# Altium schematic sheet Inresol_grid_inverter_Main.SchDoc(Inresol_grid_inverter_Main) (continued)

## Library data of the parts
C100 = C-330uF-25V-Elec-8x10-EMEA-06-09-2017: Cap 8x10 SM Alum 25V 20%; MFR: Panasonic; MFR PN: EEE-FK1E331P; Future PN (CT): TBD; Rating: 25V 20%; Component Kind: Standard; ComponentLink1Description: Datasheet; ComponentLink1URL: https://industrial.panasonic.com/ww/products/capacitors/aluminum-capacitors/aluminum-cap-smd/fk-v/EEEFK1E331P; Future PN (TR): TBD; Library Name: Inresol_grid_inverter.SchLib
C101 = C-22uF-25V-Cer-1210: Cap 1210 SM X7R 25V 10%; MFR: MURATA; MFR PN: GRM32ER71E226KE15L; Future PN (CT): TBD; Future PN (TR): 12103C226KATM; Rating: 25V 10%; Component Kind: Standard; ComponentLink1Description: Datasheet; ComponentLink1URL: http://search.murata.co.jp/Ceramy/image/img/A01X/partnumbering_e_01.pdf; Library Name: Future Capacitors.SCHLIB; Verified: AD030615
C102 C103 C106 C107 = C-820uF-450V-Elec-40x60-EMEA-19-10-2016: Cap Alu Elec 450V 820 uF 110mOhm esr 5k hrs @105°C; MFR: Vishay; MFR PN: MAL229967821E3; Future PN (CT): TBA; Component Kind: Standard; ComponentLink1Description: Datasheet; ComponentLink1URL: http://www.vishay.com/docs/28432/299phl4tsi.pdf; Future PN (TR): TBA; Library Name: Inresol_grid_inverter.SchLib
C104 C105 C108 C109 = C-4.7nF-300V-PolyF-C-12.5x5_EMEA_20122017: Cap 12.5x5 TH Polyprop 300V 20% Y2; MFR: Epcos; MFR PN: B32021A3472M000; Future PN (CT): TBD; Rating: 300Vac 20% Y2; Component Kind: Standard; ComponentLink1Description: Datasheet; ComponentLink1URL: http://www.vishay.com/docs/28114/mkp3386y2.pdf; Future PN (TR): TBD; Library Name: Inresol_grid_inverter.SchLib
C122 C129 C137 C139 C145 C146 C148 C154 = C-100pF-25V-Cer-0603: Cap 0603 SM X7R 25V 10%; MFR: AVX; MFR PN: 06033C101KAT2A; Future PN (CT): 06033C101KATN-CT; Future PN (TR): 06033C101KATN; Rating: 25V 10%; Component Kind: Standard; ComponentLink1Description: Datasheet; ComponentLink1URL: http://www.avx.com/docs/Catalogs/cx7r.pdf; Library Name: Future Capacitors.SCHLIB; Verified: AD030615
C127 C131 C133 C134 C136 = C-4.7uF-50V-Cer-1206-emea-8-10-15: Cap 1206 SM X7R 50V 10%; MFR: SAMSUNG; MFR PN: CL31B475KBHNNNE; Apr'd: raised emea 8th Oct 2015; Future PN (CT): TBA; Future PN (TR): 12065C475KATM; Rating: 50V 10%; Component Kind: Standard; ComponentLink1Description: Datasheet; ComponentLink1URL: http://www.samsungsem.com/servlet/ProductSearchView?page=1&searchIdx=1&lang=kr&partnum=1&find_val=CL31B475KBHNNNE; Library Name: EMEA CAPACITORS_FEB2016.SchLib
C128 C135 C142 C159 C161 C162 C164 = C-100nF-50V-Cer-0603: Cap 0603 SM X7R 50V 10%; MFR: AVX; MFR PN: 06035C104K4T2A; Future PN (CT): 06035C104K4TN-CT; Future PN (TR): 06035C104K4TN; Rating: 50V 10%; Component Kind: Standard; ComponentLink1Description: Datasheet; ComponentLink1URL: http://www.avx.com/docs/Catalogs/cx7r.pdf; Library Name: EMEA CAPACITORS_FEB2016.SchLib; Verified: AD030615
C130 C138 C147 C149 = C-330pF-25V-Cer-0603: Cap 0603 SM X7R 25V 1%; MFR: AVX; MFR PN: 06033A331FAT2A; Future PN (CT): TBD; Future PN (TR): 06033A331FATN; Rating: 25V 1%; Component Kind: Standard; ComponentLink1Description: Datasheet; ComponentLink1URL: http://www.avx.com/docs/Catalogs/ccog.pdf; Library Name: Future Capacitors.SCHLIB; Verified: AD030615
C140 C141 = C-10uF-50V-Cer-1206: Cap 1206 SM X5R 50V 20%; MFR: TDK; MFR PN: C3216X5R1H106M160AB; Future PN (CT): TBD; Future PN (TR): 12065D106MATN; Rating: 50V 20%; Component Kind: Standard; ComponentLink1Description: Datasheet; ComponentLink1URL: http://product.tdk.com/capacitor/mlcc/en/documents/mlcc_commercial_general_en.pdf; Library Name: EMEA CAPACITORS_FEB2016.SchLib; Verified: AD030615
C143 = C-470uF-16V-Elec-8x10-EMEA-28-10-2016: Cap 8X10 SM Alum 16V 20% 2000hrs 105degree; MFR: Panasonic; MFR PN: EEE-FK1C471P; Rating: 16V 20%; Component Kind: Standard; ComponentLink1Description: Datasheet; ComponentLink1URL: http://industrial.panasonic.com/cdbs/www-data/pdf/RDE0000/ABA0000C31.pdf; Library Name: Inresol_grid_inverter.SchLib
C144 = C-1uF-25V-Cer-0603: Cap 0603 SM X5R 25V 10%; MFR: YAGEO; MFR PN: CC0603KRX5R8BB105; Future PN (CT): 06033D105KATN-CT; Future PN (TR): 06033D105KATN; Rating: 25V 10%; Component Kind: Standard; ComponentLink1Description: Datasheet; ComponentLink1URL: http://www.yageo.com/documents/recent/UPY-GPHC_X5R_4V-to-50V_12.pdf; Library Name: Future Capacitors.SCHLIB; Verified: AD030615
C150 C151 = C-22uF-16V-Tant-1210: Cap 1210 SM Tant 16V 10%; MFR: AVX; MFR PN: TPSB226K016R0600; Future PN (CT): 226K16BP0600-CT; Future PN (TR): 226K16BP0600; Rating: 16V 10%; Component Kind: Standard; ComponentLink1Description: Datasheet; ComponentLink1URL: http://www.avx.com/docs/masterpubs/tantniob.pdf; Library Name: Future Capacitors.SCHLIB; Verified: AD030615
C152 = C-10nF-50V-Cer-1206: Cap 1206 SM X7R 50V 10%; MFR: AVX; MFR PN: 12065C103KAT2A; Changes: changed footprint to 1206 to fit track between pads.; Future PN (CT): 12065C103KATN-CT; Future PN (TR): 12065C103KATN; Rating: 50V 10%; Changes-date: 20170215; Component Kind: Standard; ComponentLink1Description: Datasheet; ComponentLink1URL: http://www.avx.com/docs/Catalogs/ccog.pdf; Library Name: Future Capacitors.SCHLIB
C153 = C-2.2uF-25V-Cer-0805-emea-8-10-15: Cap 0805 SM X7R 25V 10%; MFR: SAMSUNG; MFR PN: CL21B225KAFNNNG; Apr'd: raised emea 8th Oct 2015; Future PN (CT): TBA; Future PN (TR): 08053C225KATN; Rating: 25V 10%; Component Kind: Standard; ComponentLink1Description: Datasheet; ComponentLink1URL: http://www.samsungsem.com/servlet/ProductSearchView?page=1&searchIdx=1&lang=kr&partnum=1&find_val=CL31B475KBHNNNE; Library Name: EMEA CAPACITORS_FEB2016.SchLib
C155-C158 = C-22uF-10V-Cer-1206: Cap 1206 SM X5R 10V 20%; MFR: AVX; MFR PN: 1206ZD226MAT2A; Future PN (CT): TBD; Future PN (TR): 1206ZD226MATN; Rating: 10V 20%; Component Kind: Standard; ComponentLink1Description: Datasheet; ComponentLink1URL: http://www.avx.com/docs/Catalogs/cx5r.pdf; Library Name: Future Capacitors.SCHLIB; Verified: AD030615
C160 = C-6.8nF-50V-Cer-0603-EMEA-21-07-16: Cap 0603 SM X7R 50V 10%; MFR: VISHAY; MFR PN: VJ0603Y682KXACW1BC; Future PN (CT): 06035C682KATN-CT; Future PN (TR): 06035C682KATN; Rating: 50V 10%; Component Kind: Standard; ComponentLink1Description: Datasheet; ComponentLink1URL: http://www.vishay.com/docs/28503/x7rlv.pdf; Library Name: Lucy Zodion LoRa SLC.SchLib
C163 C165 = C-10pF-10V-Cer-0603: Cap 0603 SM X7R 10V 10%; MFR: AVX; MFR PN: 0603ZA100KAT2A; Future PN (CT): TBD; Future PN (TR): 0603ZA100KATN; Rating: 10V 10%; Component Kind: Standard; ComponentLink1Description: Datasheet; ComponentLink1URL: http://www.avx.com/docs/Catalogs/cx7r.pdf; Library Name: Future Capacitors.SCHLIB; Verified: AD030615
D100 = D-SOD123-MMSD4148T: Diode, Switching, Si, 200mA; MFR: ON SEMI; MFR PN: MMSD4148T1G; Apr'd: YES; Future PN (CT): MMSD4148T1-CT; Future PN (TR): MMSD4148T1-LF; Rating: 200mA 100V; Component Kind: Standard; ComponentLink1Description: Datasheet; ComponentLink1URL: http://www.onsemi.com/pub_link/Collateral/MMSD4148T1-D.PDF; Library Name: Future Semiconductors.SCHLIB
D101 = D-SMA-SMAJ15A-EMEA-06-09-2017: Diode TVS Uni 17.6V 400W SMA; MFR: ST; MFR PN: SMAJ15A-TR; Apr'd: YES; Future PN (CT): TBD; Component Kind: Standard; ComponentLink1Description: Datasheet; ComponentLink1URL: http://uk.futureelectronics.com/en/technologies/electromechanical/circuit-protection/tvs-diodes/Pages/7715931-SMAJ15A-TR.aspx?gclid=EAIaIQobChMIpp620-2Q1gIVyp3tCh1UKQcuEAAYBCAAEgK-VvD_BwE; Future PN (TR): TBD; Rating: 17.6V 400W; Library Name: Inresol_grid_inverter.SchLib
D102 D103 = D-SMA-MBRA340T3G: Diode Schottky 40V 3A SMD; MFR: On Semi; Apr'd: YES; Future PN (CT): MBRA340TR-CT; Future PN (TR): MBRA340TR; Component Kind: Standard; ComponentLink1Description: Datasheet; ComponentLink1URL: http://www.onsemi.com/pub_link/Collateral/MBRA340T3-D.PDF; Library Name: EMEA SEMICONDUCTORS_FEB2016.SchLib; MFR PN: MBRA340T3G
D104 D105 = D-SOD123-MMSZ5250B-20V-EMEA-19-05-16: Diode Zener 20V 500mW; MFR: DIODES INC; Apr'd: RAISED EMEA 19-05-16; Future PN (CT): TBD; Component Kind: Standard; ComponentLink1Description: Datasheet; ComponentLink1URL: http://www.farnell.com/datasheets/1670964.pdf; Future PN (TR): TBD; Library Name: EMEA SEMICONDUCTORS_FEB2016.SchLib; MFR PN: MMSZ5250B; Signal Integrity: D Zener; Simulation: ZENER; Value: MMSZ5250B
D106 D107 = D-SOD323-SD107WS-7-F: Diode Schottky 30V 750mA SMD; MFR: DIO; Apr'd: YES; Future PN (CT): SD107WSTR-CT; Future PN (TR): SD107WSTR; Component Kind: Standard; ComponentLink1Description: Datasheet; ComponentLink1URL: http://www.diodes.com/datasheets/ds30129.pdf; Library Name: Future Semiconductors.SCHLIB
  D106: MFR PN: SD107WS-7-F
FID1-FID8 = W-Fiducial-1mm-emea-21-7-15: Fiducial; Future PN (CT): NONE; Future PN (TR): TBD; Value: 1.5MM; Component Kind: Standard; Library Name: EMEA MISC_FEB2016.SchLib; MFR: NONE; MFR PN: NONE; Rating: Fiducial; Signal Integrity: Connector
H1-H12 = W-Mounting Hole-non plated-M4-EMEA: Mounting Hole-non plated-M4; Future PN (CT): NONE; Future PN (TR): TBD; Rating: MountHole non plated M4; Value: 170mil; MFR: NONE; MFR PN: NONE; Signal Integrity: Connector; Library Name: Inresol_grid_inverter.SchLib
J100 = J-HDR100-2x12-TH-KEYED: Header, Male 24-Pin,  LS100mil, Dual row, KEYED; MFR: Amphenol; Future PN (CT): TBD; Value: 24 Pin Keyed; Component Kind: Standard; ComponentLink1Description: Datasheet; ComponentLink1URL: https://uk.rs-online.com/web/p/products/8323597/?grossPrice=Y&cm_mmc=UK-PPC-DS3A-_-google-_-3_PCB+Headers_Amphenol_Exact-_-Amphenol+-+PCB+Headers+-+8323597-_-t821124a1s100ceu&matchtype=e&gclid=EAIaIQobChMI5u_5xpSZ2AIVqr_tCh0ofABGEAAYAiAAEgLcq_D_BwE&gclsrc=aw.ds; Future PN (TR): TBD; Signal Integrity: Connector; Library Name: Inresol_grid_inverter.SchLib
J101 = J-5.08MM-TERMINAL-2-WAY-282837-2-4-9-15EMEA: 5.08MM PITCH TERMINALS 2-WAY 13.5A, 250VAC; MFR: TE CONNECTIVITY; Apr'd: Raised EMEA 24th Mar 2013; Rating: 250VAC 13.5A; Value: 3-WAY TERMINAL STRIP; Component Kind: Standard; ComponentLink1Description: Datasheet; ComponentLink1URL: http://www.te.com/catalog/pn/en/282837-2?RQPN=282837-2; Future PN (TR): 282837-2; Library Name: EMEA CONNECTORS_FEB2016.SchLib; MFR PN: 282837-2
K103 = K-Panasonic-Relay-SPST-NO-35A-12V-EMEA-07-09-2017: K-Relay, SPST-NO 35A 12VDC; Future PN (CT): TBD; Rating: SPST-NO 12VDC, 250VAC 31A; Component Kind: Standard; ComponentLink1Description: Datasheet; ComponentLink1URL: http://www3.panasonic.biz/ac/e/search_num/index.jsp?c=detail&part_no=ALFG2PF121; Future PN (TR): TBD; MFR: Panasonic; Simulation: SPSTRELAY; Library Name: Inresol_grid_inverter.SchLib
L100 = L-IND2.5X2-10uH-155mA-EMEA_20122017: INDUCTOR High frequency, 10uH, 155mA, 3.5Ohm, SRF 33MHz; MFR: TDK; MFR PN: NLV25T-100J-EF; Future PN (CT): TBD; Component Kind: Standard; ComponentLink1Description: Datasheet; ComponentLink1URL: http://www.abracon.com/Magnetics/new/AISM-1008.pdf; Future PN (TR): TBD; Library Name: Inresol_grid_inverter.SchLib
L102-L106 = L-BLM21P-0805-330Ohm-1.5A-EMEA-06-09-2017: Ferrite Bead, 330ohm@100MHz, 0.09Ohm, 1.5A, 0805; MFR: MURATA; MFR PN: BLM21PG331SN1D; Future PN (CT): BLM21P331SGPT-CT; Future PN (TR): BLM21P331SGPT; Component Kind: Standard; ComponentLink1Description: Datasheet; ComponentLink1URL: http://www.murata.com/products/catalog/pdf/c31e.pdf; Library Name: Inresol_grid_inverter.SchLib
  L104: Value: 330Ohm@100MHz
L107 = L-INDSMD6X6-10uH_3A: POWER INDUCTOR, 10uH, 3A (46mOhm); MFR: Taiyo; MFR PN: NR6045T100M; Future PN (CT): TBD; Component Kind: Standard; ComponentLink1Description: Datasheet; ComponentLink1URL: http://www.yuden.co.jp/productdata/catalog/en/wound04_e.pdf; Future PN (TR): TBD; Library Name: Future Misc.SCHLIB
L108 = L-IND30X30-1uH-2.05A-emea-12-11-15: INDUCTOR POWER 1uH, 2.05A, 44mOhm, SRF 130MHz; MFR: MURATA; Future PN (TR): LQH3NPN1R0MM0L; Component Kind: Standard; ComponentLink1Description: Datasheet; ComponentLink1URL: http://psearch.en.murata.com/inductor/product/LQH3NPN1R0NG0%23.html; MFR PN: LQH3NPN1R0MM0L; Library Name: Inresol_grid_inverter.SchLib
Q100 Q103 Q105 Q106 = Q-SOT23-BC847BLT1G: Transistor NPN SW, 45V 100mA SOT23; MFR: ON SEMI; Apr'd: YES; Future PN (CT): BC847BLT1-CT; Component Kind: Standard; ComponentLink1Description: Datasheet; ComponentLink1URL: http://www.onsemi.com/pub_link/Collateral/BC846ALT1-D.PDF; Future PN (TR): BC847BLT1; Library Name: Future Semiconductors.SCHLIB; Signal Integrity: MMBT2222A; Simulation: QMMBT2222A
  Q100: Rating: 45V 100mA
Q101 Q104 = Q-PMV45EN2R: Mosfet N-Chan SOT23 30Vds 5.4A 42mOhmRds-EMEA-10-10-2016; MFR: NXP; Future PN (CT): TBD; Component Kind: Standard; ComponentLink1Description: Datasheet; ComponentLink1URL: http://images.ihscontent.net/vipimages/VipMasterIC/IC/PHGL/PHGLS23686/PHGLS23686-1.pdf; Future PN (TR): TBD; Rating: 30Vds 5.4A; Library Name: Inresol_grid_inverter.SchLib
Q102 Q107 = Q-SOT23-BC857BLT1G: Transistor PNP SW, 45V 100mA SOT23; MFR: ON SEMI; Apr'd: YES; Future PN (CT): BC857BLT1-CT; Component Kind: Standard; ComponentLink1Description: Datasheet; ComponentLink1URL: http://www.onsemi.com/pub_link/Collateral/BC856ALT1-D.PDF; Future PN (TR): BC857BLT1; Library Name: Future Semiconductors.SCHLIB
R100 R101 R103-R105 = R-47k-1W-2512-EMEA-07-09-2017: Res 2512 SM 1W 1%; MFR: STACKPOLE; MFR PN: RMCF2512FT47K0; Future PN (CT): TBD; Rating: 1W 1%; Component Kind: Standard; ComponentLink1Description: Datasheet; ComponentLink1URL: https://www.seielect.com/Catalog/SEI-RMCF_RMCP.pdf; Future PN (TR): TBD; Library Name: Inresol_grid_inverter.SchLib
R102 = R-27k-1W-2512-EMEA-07-09-2017: Res 2512 SM 1W 1%; MFR: STACKPOLE; MFR PN: RMCF2512FT27K0; Future PN (CT): TBD; Rating: 1W 1%; Component Kind: Standard; ComponentLink1Description: Datasheet; ComponentLink1URL: https://www.seielect.com/Catalog/SEI-RMCF_RMCP.pdf; Future PN (TR): TBD; Library Name: Inresol_grid_inverter.SchLib
R106 R117-R119 R128-R130 R136-R138 R143-R145 R156-R158 = R-249k-1W-2512-EMEA-25-08-2017: Res 2512 249k SM 1W 1%; MFR: Vishay; MFR PN: CRCW2512249KFKEG; Future PN (CT): TBD; Component Kind: Standard; ComponentLink1Description: Datasheet; ComponentLink1URL: http://www.vishay.com/doc?20035; Future PN (TR): TBD; Library Name: Inresol_grid_inverter.SchLib
R107 R149 = R-44.2k-100mW -0603: Res 0603 SM 100mW 1% 100ppm; MFR: VISHAY; MFR PN: CRCW060344K2FKEA; Future PN (CT): CR0603-4422FTR-CT; Future PN (TR): CR0603-4422FTR; Component Kind: Standard; ComponentLink1Description: Datasheet; ComponentLink1URL: http://www.vishay.com/docs/20035/dcrcwe3.pdf; Library Name: Future Resistors.SCHLIB; Rating: 100mW 1%
R108 R111 R151 = R-0R-250mW-1206: Res 1206 SM 250mW; MFR: YAGEO; MFR PN: RC1206JR-070RL; Future PN (CT): CR1206-0000JTR-CT; Future PN (TR): CR1206-0000JTR; Component Kind: Standard; ComponentLink1Description: Datasheet; ComponentLink1URL: http://www.yageo.com/documents/recent/PYu-RC1206_51_RoHS_L_4.pdf; Library Name: Future Resistors.SCHLIB; Rating: 250mW
R109 R123 R155 = R-0R-100mW-0603: Res 0603 SM 100mW; MFR: YAGEO; MFR PN: RC0603JR-070RL; Future PN (CT): CR0603-0000JTR-R-CT; Future PN (TR): CR0603-0000JTR-R; Component Kind: Standard; ComponentLink1Description: Datasheet; ComponentLink1URL: http://www.rohm.com/products/databook/r/pdf/mcr03.pdf; Library Name: Future Resistors.SCHLIB; Rating: 100mW
R110 R114 = R-0R-1W-2512: Res 2512 SM 1W; MFR: VISHAY; MFR PN: CRCW25120000Z0EG; Future PN (CT): CR2512-0000JTR-CT; Future PN (TR): CR2512-0000JTR; Component Kind: Standard; ComponentLink1Description: Datasheet; ComponentLink1URL: http://www.vishay.com/docs/20035/dcrcwe3.pdf; Library Name: Future Resistors.SCHLIB; Rating: 1W
R112 R122 R133 = R-10R-1W-2512-EMEA-07-09-2017: Res 2512 SM 1W 5%; MFR: YAGEO; MFR PN: RC2512JK-0710RL; Future PN (CT): TBD; Rating: 1W 1%; Component Kind: Standard; ComponentLink1Description: Datasheet; ComponentLink1URL: http://www.yageo.com/documents/recent/PYu-RC2512_51_RoHS_L_4.pdf; Future PN (TR): TBD; Library Name: Inresol_grid_inverter.SchLib
R113 R120 R131 R134 R135 R139 R146 R148 = R-22k-100mW-0603: Res 0603 SM 100mW 1% 100ppm; MFR: YAGEO; MFR PN: RC0603FR-0722KL; Future PN (CT): CR0603-2202FTR-CT; Future PN (TR): CR0603-2202FTR; Component Kind: Standard; ComponentLink1Description: Datasheet; ComponentLink1URL: http://www.yageo.com/documents/recent/PYu-RC0603_51_RoHS_L_4.pdf; Library Name: Future Resistors.SCHLIB; Rating: 100mW 1%
R115 R125 = R-1K-1W-2512-EMEA: Res 2512 1K 5% 1W; MFR: VISHAY; MFR PN: CRCW25121K00JNEG; Apr'd: Raised EMEA 27th MAR 2013; Future PN (CT): TBA; Future PN (TR): CR2512-1001JTR; Component Kind: Standard; ComponentLink1Description: Datasheet; ComponentLink1URL: http://www.vishay.com/docs/20035/dcrcwe3.pdf; Library Name: EMEA RESISTORS_FEB2016.SchLib; Signal Integrity: Res; Simulation: RESISTOR
R116 R132 R140 R147 = R-33k-100mW-0603: Res 0603 SM 100mW 1% 100ppm; MFR: YAGEO; MFR PN: RC0603FR-0733KL; Future PN (CT): CR0603-3302FTR-CT; Future PN (TR): CR0603-3302FTR; Component Kind: Standard; ComponentLink1Description: Datasheet; ComponentLink1URL: http://www.yageo.com/documents/recent/PYu-RC0603_51_RoHS_L_4.pdf; Library Name: Future Resistors.SCHLIB; Rating: 100mW 1%
R121 = R-100R-100mW-0603: Res 0603 SM 100mW 1% 100ppm; MFR: YAGEO; MFR PN: RC0603FR-07100RL; Future PN (CT): CR0603-1000FTR-CT; Future PN (TR): CR0603-1000FTR; Component Kind: Standard; ComponentLink1Description: Datasheet; ComponentLink1URL: http://www.yageo.com/documents/recent/PYu-RC0603_51_RoHS_L_4.pdf; Library Name: Future Resistors.SCHLIB; Rating: 100mW 1%
R124 R141 R142 R154 = R-8.2k-100mW-0603: Res 0603 SM 100mW 1% 100ppm; MFR: YAGEO; MFR PN: RC0603FR-078K2L; Future PN (CT): CR0603-8201FTR-CT; Future PN (TR): CR0603-8201FTR; Component Kind: Standard; ComponentLink1Description: Datasheet; ComponentLink1URL: http://www.yageo.com/documents/recent/PYu-RC0603_51_RoHS_L_4.pdf; Library Name: Future Resistors.SCHLIB; Rating: 100mW 1%
R126 R127 R150 = R-10k-100mW-0603: Res 0603 SM 100mW 1% 100ppm; MFR: YAGEO; MFR PN: RC0603FR-0710KL; Future PN (CT): CR0603-1002FTR-CT; Future PN (TR): CR0603-1002FTR; Component Kind: Standard; ComponentLink1Description: Datasheet; ComponentLink1URL: http://www.yageo.com/documents/recent/PYu-RC0603_51_RoHS_L_4.pdf; Library Name: Future Resistors.SCHLIB; Rating: 100mW 1%
R152 = R-100k-100mW-0603: Res 0603 SM 100mW 1% 100ppm; MFR: ROHM; MFR PN: MCR03EZPFX1003; Future PN (CT): CR0603-1003FTR-CT; Future PN (TR): CR0603-1003FTR; Component Kind: Standard; ComponentLink1Description: Datasheet; ComponentLink1URL: http://www.rohm.com/products/databook/r/pdf/mcr03.pdf; Library Name: Future Resistors.SCHLIB; Rating: 100mW 1%
R153 = R-6.8k-100mW-0603: Res 0603 SM 100mW 1% 100ppm; MFR: YAGEO; MFR PN: RC0603FR-076K8L; Future PN (CT): CR0603-6801FTR-CT; Future PN (TR): CR0603-6801FTR; Component Kind: Standard; ComponentLink1Description: Datasheet; ComponentLink1URL: http://www.yageo.com/pdf/Pu-RC0603_51_PbFree_L_2.pdf; Library Name: Future Resistors.SCHLIB; Rating: 100mW 1%
R159 = R-68R-1W-2512-EMEA-20-12-2017: Res 2512 68R SM 1W 5%; MFR: YAGEO; MFR PN: RC2512JK-0768RL; Future PN (CT): TBD; Component Kind: Standard; ComponentLink1Description: Datasheet; ComponentLink1URL: http://www.futureelectronics.com/en/Search.aspx?dsNav=Ntk:PartNumberSearch%7CRC2512JK%2F-0768RL%7C1%7C,Ny:True,Ro:0,Nea:True; Future PN (TR): TBD; Value: 68R; Library Name: Inresol_grid_inverter.SchLib
TP100-TP120 = TP-TEST POINT-LOOP WT-EMEA-15-10-15: Test Point; MFR: NA; Future PN (CT): NONE; Future PN (TR): TBD; ComponentLink1Description: Datasheet; Library Name: EMEA MISC_FEB2016.SchLib; MFR PN: NA; Signal Integrity: Connector
U101 = U-SOIC8-LM7322MA-EMEA_20122017: IC, Gen Purpose Dual OpAmp LM7322MA; MFR: TI; Future PN (TR): NA; Rating: 5V; Component Kind: Standard; ComponentLink1Description: Datasheet; ComponentLink1URL: http://uk.farnell.com/fairchild-semiconductor/lmv358am8x/ic-op-amp-1-4mhz-1-5v-us-soic-8/dp/1262552; Library Name: Inresol_grid_inverter.SchLib
U102 = U-SO8-AP3502EMTR-G1-EMEA-23-08-2017: in 4.5V-18V; 2A; 340kHz PWM SYNC DC/DC BUCK; MFR: DIODES; Apr'd: Raised EMEA 23-08-2017; Rating: Vin 4.5V-18V; 2A; 340kHz PWM; Component Kind: Standard; ComponentLink1Description: Datasheet; ComponentLink1URL: https://www.diodes.com/assets/Datasheets/AP3502E.pdf; MFR PN: AP3502EMTR-G1; Library Name: Inresol_grid_inverter.SchLib
U103 = U-AP2204RA-3.3TRG1-EMEA-30-06-16: 150mA ULDO REGULATOR SOT89 3.3Vout; MFR: DIODES; Future PN (CT): TBD; Rating: 2.3-24Vip 3.3Vout; Component Kind: Standard; ComponentLink1Description: Datasheet; ComponentLink1URL: http://www.diodes.com/_files/datasheets/AP2204.pdf; MFR PN: AP2204RA-3.3TRG1; Library Name: Inresol_grid_inverter.SchLib
U104 = U-NCP1117LPST33T3G: PMIC LDO Regulator SOT223 SM UpTo 18Vin 1A 3.3Vout; MFR: ON SEMI; Future PN (CT): TBD; Future PN (TR): LT1117CST-3.3TR-LP; VModel: xxMMDDYY; Component Kind: Standard; ComponentLink1Description: Datasheet; ComponentLink1URL: http://images.ihscontent.net/vipimages/VipMasterIC/IC/ONSM/ONSM-S-A0000202102/ONSM-S-A0000202102-1.pdf; Library Name: Future Semiconductors.SCHLIB; MFR PN: NCP1117LPST33T3G; Rating: UpTo 18Vin 1A; Verified: AD032816
W100 W201 W301 W401 = J-WAGO_745-304_blocks: Connector WAGO 4 pin; Future PN (CT): TBD; Component Kind: Standard; ComponentLink1Description: Datasheet; ComponentLink1URL: http://www.wago.us/search/index.jsp?_ts=1479484242100&action=morearticledownloads&q=745-304; Future PN (TR): TBD; MFR: WAGO; MFR PN: 745-304; Signal Integrity: Connector; Value: WAGO; Library Name: Inresol_grid_inverter.SchLib
